# Altium schematic sheet 04_Debug_Port.SchDoc
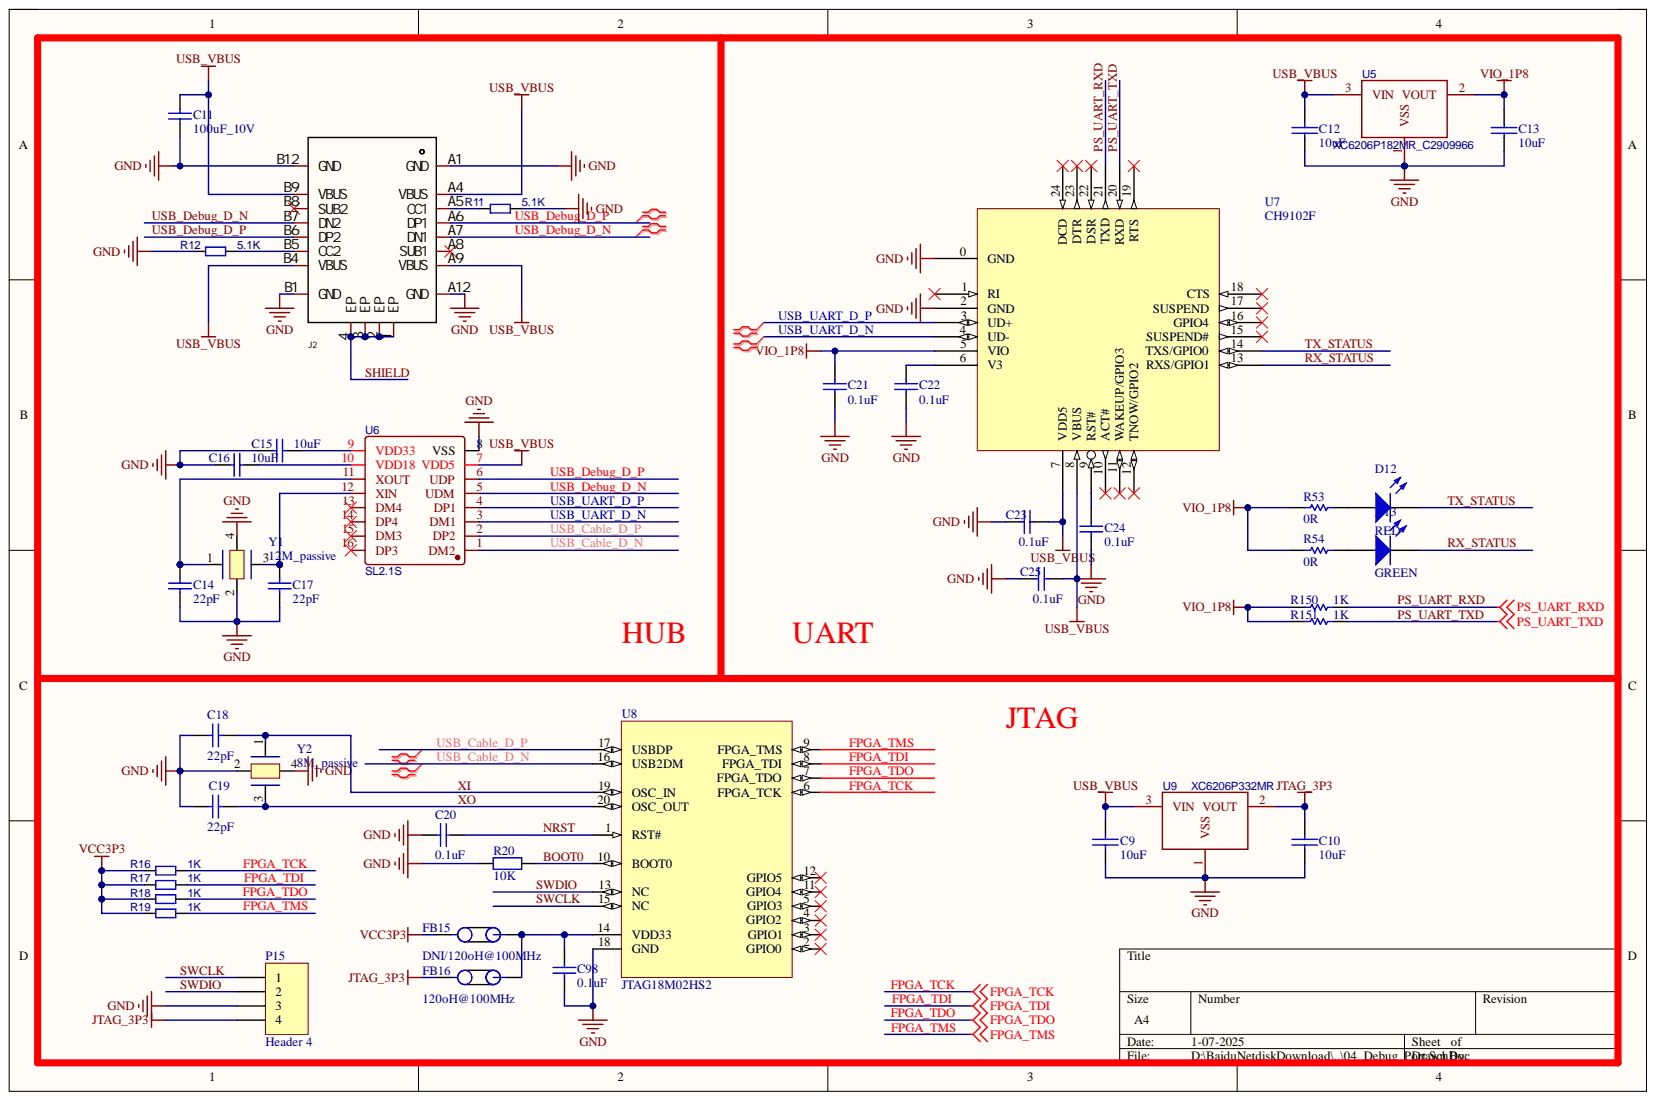
[diagram p0: 04_Debug_Port.SchDoc]

## Parts
C9  10uF  [C0805]
C10  10uF  [C0805]
C11  100uF_10V  [C1206]
C12  10uF  [C0805]
C13  10uF  [C0805]
C14  22pF  [C0603]
C15  10uF  [C0805]
C16  10uF  [C0805]
C17  22pF  [C0603]
C18  22pF  [C0603]
C19  22pF  [C0603]
C20  0.1uF  [C0603]
C21  0.1uF  [C0603]
C22  0.1uF  [C0603]
C23  0.1uF  [C0603]
C24  0.1uF  [C0603]
C25  0.1uF  [C0603]
C98  0.1uF  [C0603]
D12  RED  [0603_LED]
D13  GREEN  [0603_LED]
FB15  DNI/120oH@100MHz  [L0603]
FB16  120oH@100MHz  [L0603]
J2  KH-TYPE-C-16P  [USB_Type-c]  (value not drawn)
P15  Header 4  [hdr-th_4p-p1_27-v-f]
R11  5.1K  [0603R]
R12  5.1K  [0603R]
R16  1K  [0603R]
R17  1K  [0603R]
R18  1K  [0603R]
R19  1K  [0603R]
R20  10K  [0603R]
R53  0R  [0603R]
R54  0R  [0603R]
R150  1K  [0603R]
R151  1K  [0603R]
U5  XC6206P182MR_C2909966  [SOT-23]
U6  SL2.1S  [SSOP-16_L4_6-W2_6-P0_53-LS4_0]
U7  CH9102F  [qfn24_4x4]
U8  JTAG18M02HS2  [TSSOP20]
U9  XC6206P332MR  [SOT-23]
Y1  12M_passive  [X4-3225]
Y2  8M_passive  [X4-3225]

## Nets
GND: C9.1 C10.1 C11.1 C12.1 C13.1 C14.1 C15.2 C16.2 C17.1 C18.2 C19.2 C20.1 C21.2 C22.2 C23.2 C24.1 C25.2 C98.2 J2.A1(GND) J2.A12(GND) J2.B1(GND) J2.B12(GND) P15.3 R11.2 R12.1 R20.2 U5.1 U6.8(VSS) U7.0(GND) U7.2(GND) U8.18(GND) U9.1 Y1.2 Y1.4 Y2.2 Y2.4
JTAG_3P3: C10.2 FB16.1 P15.4 U9.2
USB_VBUS: C9.2 C11.2 C12.2 C23.1 C25.1 J2.A4(VBUS) J2.A9(VBUS) J2.B4(VBUS) J2.B9(VBUS) U5.3 U6.7(VDD5) U7.7(VDD5) U7.8(VBUS) U9.3
VCC3P3: FB15.1 R16.1 R17.1 R18.1 R19.1
VIO_1P8: C13.2 C21.1 R53.2 R54.2 R150.2 R151.2 U5.2 U7.5(VIO)
BOOT0: R20.1 U8.10(BOOT0)
FPGA_TCK: R16.2 U8.6(FPGA_TCK)
FPGA_TDI: R17.2 U8.8(FPGA_TDI)
FPGA_TDO: R18.2 U8.7(FPGA_TDO)
FPGA_TMS: R19.2 U8.9(FPGA_TMS)
NRST: C20.2 U8.1(RST#)
PS_UART_RXD: R150.1 U7.21(TXD)
PS_UART_TXD: R151.1 U7.20(RXD)
RX_STATUS: D13.2 U7.13(RXS/GPIO1)
SHIELD: J2.1(EP) J2.2(EP) J2.3(EP) J2.4(EP)
SWCLK: P15.1 U8.15(NC)
SWDIO: P15.2 U8.13(NC)
TX_STATUS: D12.2 U7.14(TXS/GPIO0)
USB_Cable_D_N: U6.1(DM2) U8.16(USB2DM)
USB_Cable_D_P: U6.2(DP2) U8.17(USBDP)
USB_Debug_D_N: J2.A7(DN1) J2.B7(DN2) U6.5(UDM)
USB_Debug_D_P: J2.A6(DP1) J2.B6(DP2) U6.6(UDP)
USB_UART_D_N: U6.3(DM1) U7.4(UD-)
USB_UART_D_P: U6.4(DP1) U7.3(UD+)
XI: C18.1 U8.19(OSC_IN) Y2.1
XO: C19.1 U8.20(OSC_OUT) Y2.3
NetC14_2: C14.2 U6.11(XOUT) Y1.1
NetC15_1: C15.1 U6.9(VDD33)
NetC16_1: C16.1 U6.10(VDD18)
NetC17_2: C17.2 U6.12(XIN) Y1.3
NetC22_1: C22.1 U7.6(V3)
NetC24_2: C24.2 U7.9(RST#)
NetC98_1: C98.1 FB15.2 FB16.2 U8.14(VDD33)
NetD12_1: D12.1 R53.1
NetD13_1: D13.1 R54.1
NetJ2_A5: J2.A5(CC1) R11.1
NetJ2_B5: J2.B5(CC2) R12.2

Not connected: J2 A8(SUB1) B8(SUB2); U6 13(DM4) 14(DP4) 15(DM3) 16(DP3); U7 1(RI) 10(ACT#) 11(WAKEUP/GPIO3) 12(TNOW/GPIO2) 15(SUSPEND#) 16(GPIO4) 17(SUSPEND) 18(CTS) 19(RTS) 22(DSR) 23(DTR) 24(DCD); U8 2(GPIO0) 3(GPIO1) 4(GPIO2) 5(GPIO3) 11(GPIO4) 12(GPIO5)

## Library data of the parts
C9 C10 C12 C13 = CAP: Capacitor; Component Kind: Standard; Value: 10uF
C11 C14 C17 = Cap: Capacitor; Component Kind: Standard; LatestRevisionDate: 17-Jul-2002; LatestRevisionNote: Re-released for DXP Platform.; Library Name: Miscellaneous Devices.IntLib; PackageDescription: Radial Cap, Thru-Hole; 2 Leads; 0.3 in Pin Spacing; PackageReference: RAD-0.3; Published: 8-Jun-2000; Signal Integrity: Cap; Simulation: CAP; Publisher: Altium Limited
  C11: Value: 100uF_10V
  C14 C17: Value: 22pF
C15 C16 = Cap1: Capacitor; Component Kind: Standard; LatestRevisionDate: 17-Jul-2002; LatestRevisionNote: Re-released for DXP Platform.; PackageReference: RAD-0.3; Published: 8-Jun-2000; Value: 10uF; Library Name: weifengdq.IntLib; Publisher: Altium Limited
C18-C25 C98 = Cap_1: Capacitor; Component Kind: Standard; LatestRevisionDate: 17-Jul-2002; LatestRevisionNote: Re-released for DXP Platform.; Library Name: zynq.SCHLIB; PackageDescription: Radial Cap, Thru-Hole; 2 Leads; 0.3 in Pin Spacing; PackageReference: RAD-0.3; Published: 8-Jun-2000; Signal Integrity: Cap; Simulation: CAP; Publisher: Altium Limited
  C18 C19: Value: 22pF
  C20-C25 C98: Value: 0.1uF
D12 D13 = LED2: Typical RED, GREEN, YELLOW, AMBER GaAs LED; Component Kind: Standard; LatestRevisionDate: 17-Jul-2002; LatestRevisionNote: Re-released for DXP Platform.; Library Name: Miscellaneous Devices.IntLib; PackageDescription: SM LED; 2 Flat Leads; PackageReference: 3.2X1.6X1.1; PackageVersion: Feb-2002; Published: 8-Jun-2000; Publisher: Altium Limited
  D12: Value: RED
  D13: Value: GREEN
FB15 FB16 = CiZhu: Component Kind: Standard; Description: CiZhu; Library Name: zynq.SCHLIB
  FB15: Value: DNI/120oH@100MHz
  FB16: Value: 120oH@100MHz
J2 = KH-TYPE-C-16P: Manufacturer: kinghelm(金航标); Add into BOM: yes; Connector Type: Type-C; Current Rating - Power (Max): 3A; Gender: 母座; Number of Contacts: 16; Number of Ports: 1; Component Kind: Standard; Convert to PCB: yes; Datasheet: https://atta.szlcsc.com/upload/public/pdf/source/20200911/C709357_AA8DCEB38BB20E3035A7ED7AE7667837.pdf; Device: KH-TYPE-C-16P; JLCPCB Part Class: Extended Part; LCSC Part Name: Type-C 母座 卧贴; Manufacturer Part: KH-TYPE-C-16P; Mounting Style: 卧贴; Name: KH-TYPE-C-16P; Operating Temperature Range: -40℃~+85℃; PCB3D: USB-C-SMD_KH-TYPE-C-16P; Standard: -; Supplier: LCSC; Supplier Footprint: SMD; Supplier Part: C709357; Symbol: KH-TYPE-C-16P; Library Name: Gowin.SchLib
P15 = Header 4: Header, 4-Pin; Component Kind: Standard; LatestRevisionDate: 17-Jul-2002; LatestRevisionNote: Re-released for DXP Platform.; Library Name: Miscellaneous Connectors.IntLib; Signal Integrity: Connector; Publisher: Altium Limited
R11 R12 R16-R19 = R: Value: DNI/4K7; Component Kind: Standard; Library Name: zynq.SCHLIB
R20 = Res2_1: Resistor; Component Kind: Standard; LatestRevisionDate: 17-Jul-2002; LatestRevisionNote: Re-released for DXP Platform.; Library Name: zynq.SCHLIB; PackageReference: AXIAL-0.4; Published: 8-Jun-2000; Value: 10K; Publisher: Altium Limited
R53 R54 R150 R151 = Res1: Resistor; Component Kind: Standard; LatestRevisionDate: 17-Jul-2002; LatestRevisionNote: Re-released for DXP Platform.; Library Name: Miscellaneous Devices.IntLib; PackageDescription: Axial Device, Thru-Hole; 2 Leads; 0.3 in Pin Spacing; PackageReference: AXIAL-0.3; Published: 8-Jun-2000; Signal Integrity: Res; Simulation: RESISTOR; Publisher: Altium Limited
  R53 R54: Value: 0R
  R150 R151: Value: 1K
U5 U9 = XC6206P182MR_C2909966: BOM_Manufacturer: YONGYUTAI(永裕泰); Contributor: LCSC; BOM_Manufacturer Part: XC6206P182MR; BOM_Supplier: LCSC; BOM_Supplier Part: C2909966; Component Kind: Standard; Library Name: zynq.SCHLIB; spicePre: U; spiceSymbolName: XC6206P182MR_C2909966
  U5: Description: XC6206P182MR_C2909966
  U9: Description: XC6206P332MR
U6 = SL2.1S: BOM_Manufacturer: CoreChips(和芯润德); Contributor: LCSC; BOM_JLCPCB Part Class: Extended Part; BOM_Manufacturer Part: SL2.1s; BOM_Supplier: LCSC; BOM_Supplier Part: C2684433; Component Kind: Standard; Description: SL2.1S; Library Name: zynq.SCHLIB; spicePre: U; spiceSymbolName: SL2.1S
U7 = CH9102F: USB to high speed TTL Serial / UART; Component Kind: Standard; Library Name: CHXXX.schlib
Y1 = OSC: Component Kind: Standard; Library Name: SCHLIB.SchLib
Y2 = OSC_2: Component Kind: Standard; Description: 8M_passive; Library Name: zynq.SCHLIB
U8 = JTAG18M02HS2: Component Kind: Standard; Description: JTAG18M02HS2; Library Name: zynq.SCHLIB
